# Altium schematic sheet NRC7394-RX.SchDoc
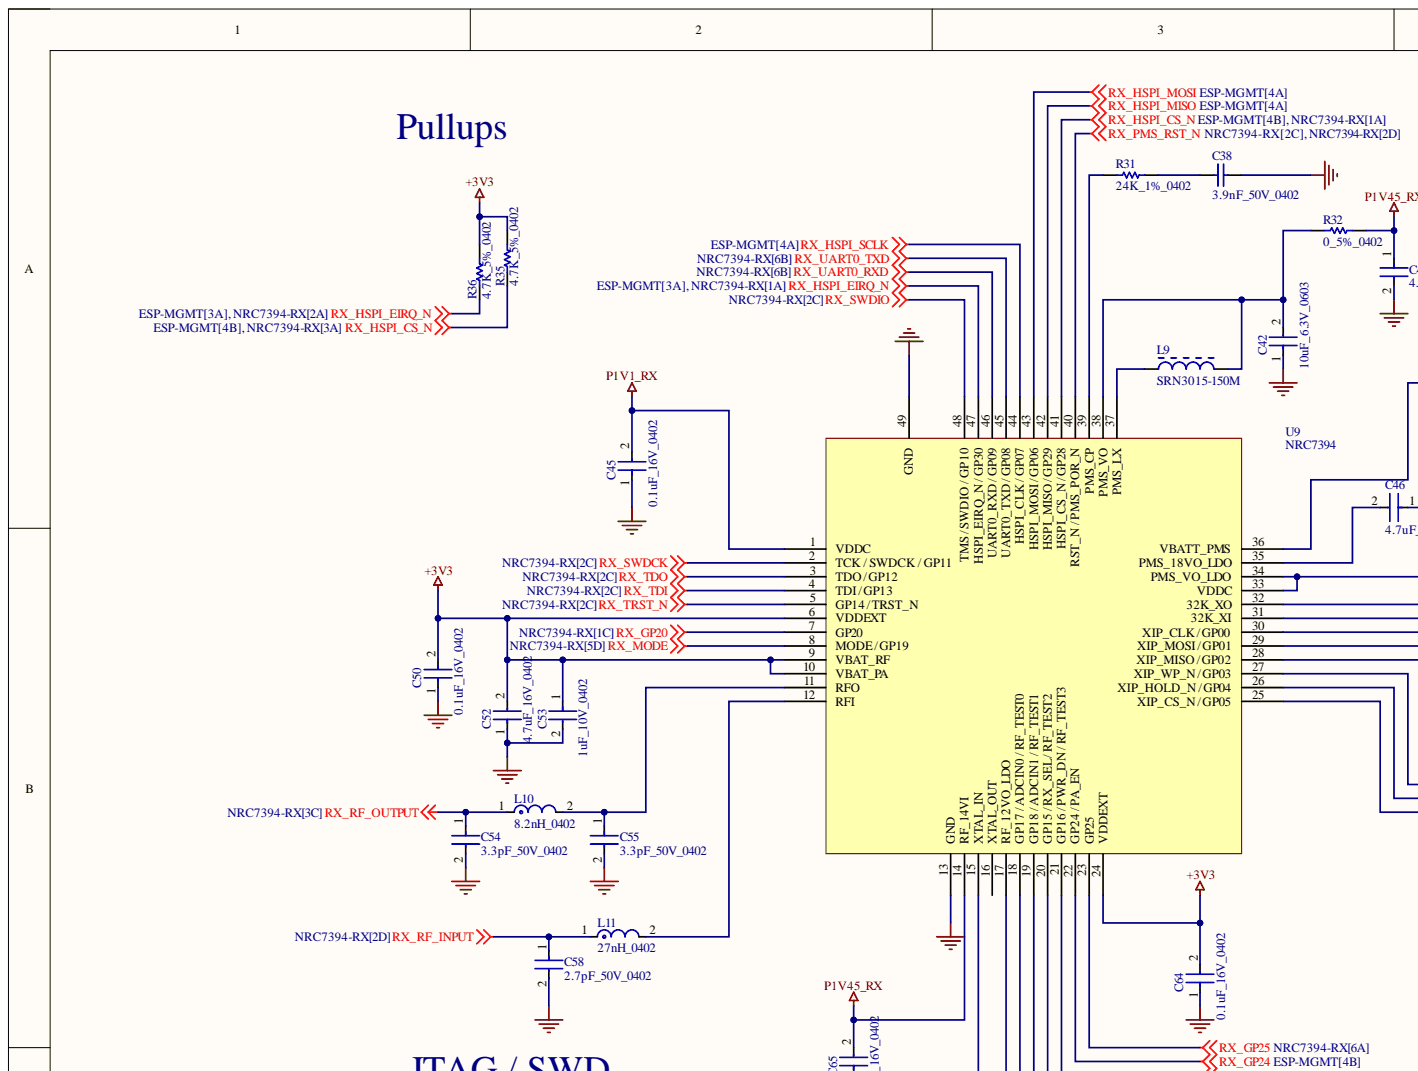
[diagram p0: NRC7394-RX.SchDoc, top left]
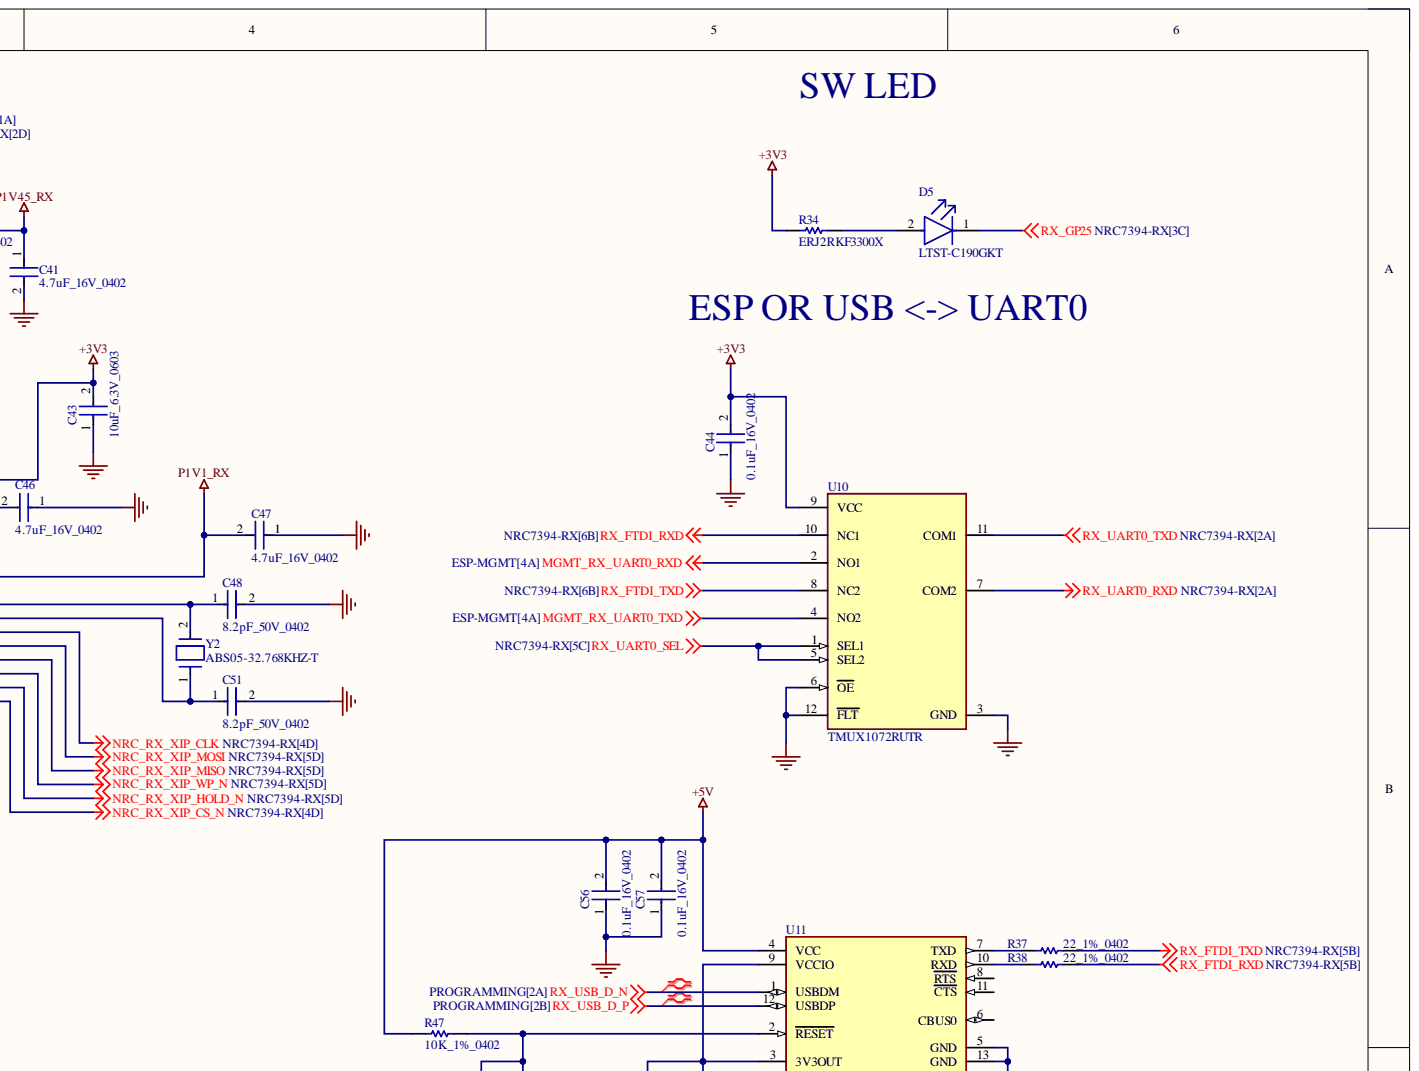
[diagram p1: NRC7394-RX.SchDoc, top right]
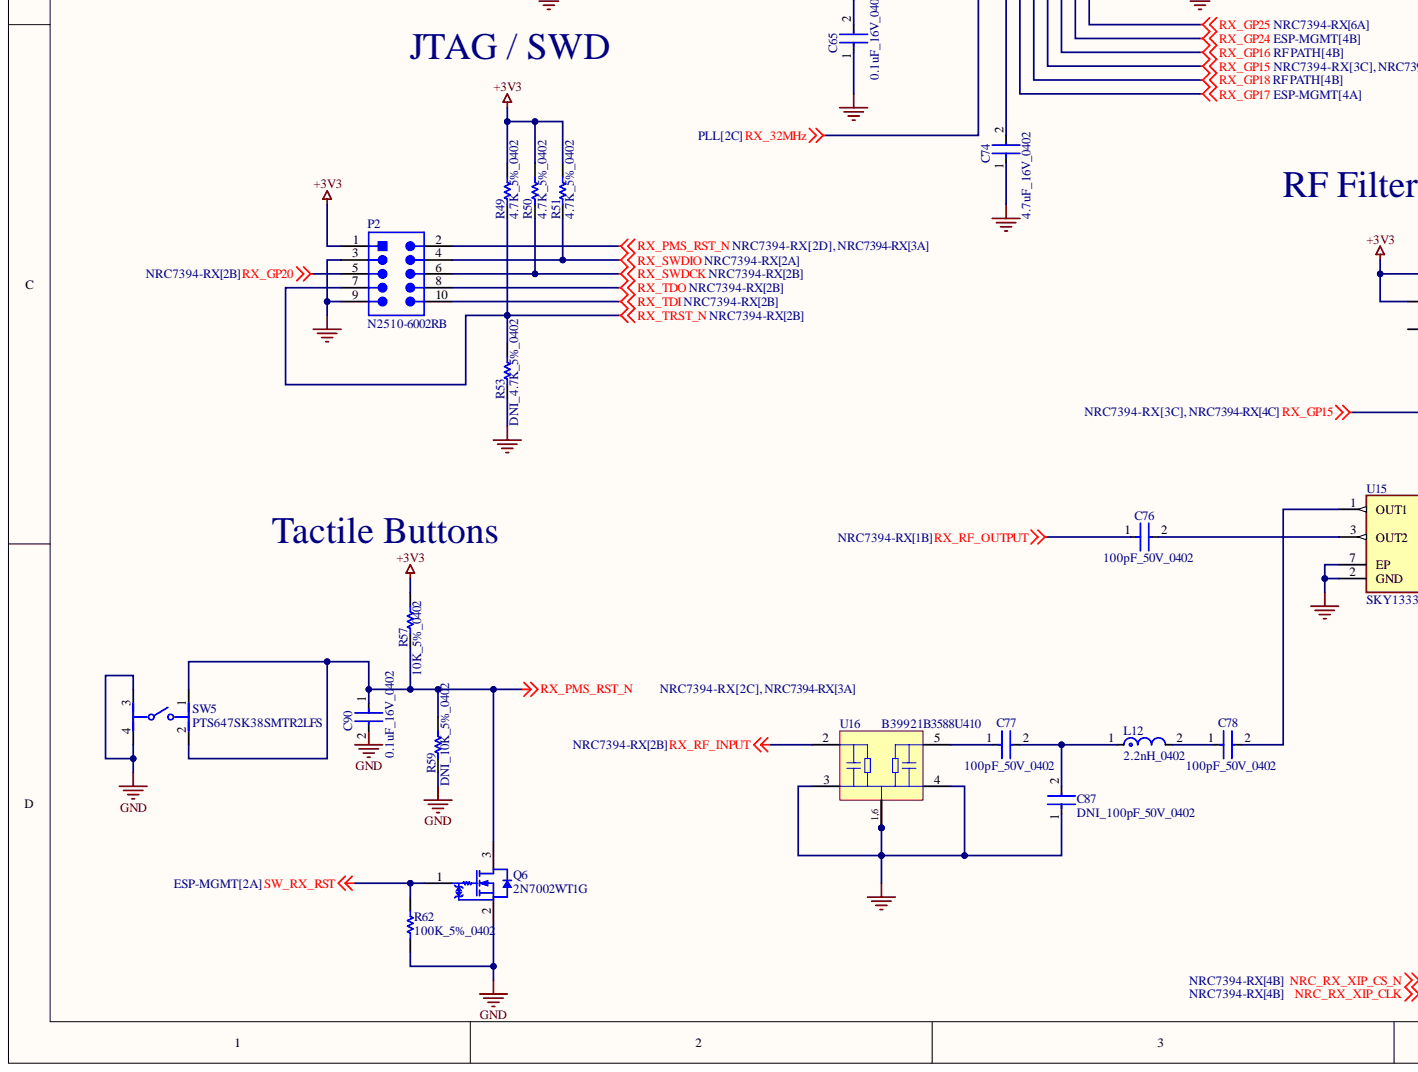
[diagram p2: NRC7394-RX.SchDoc, bottom left]
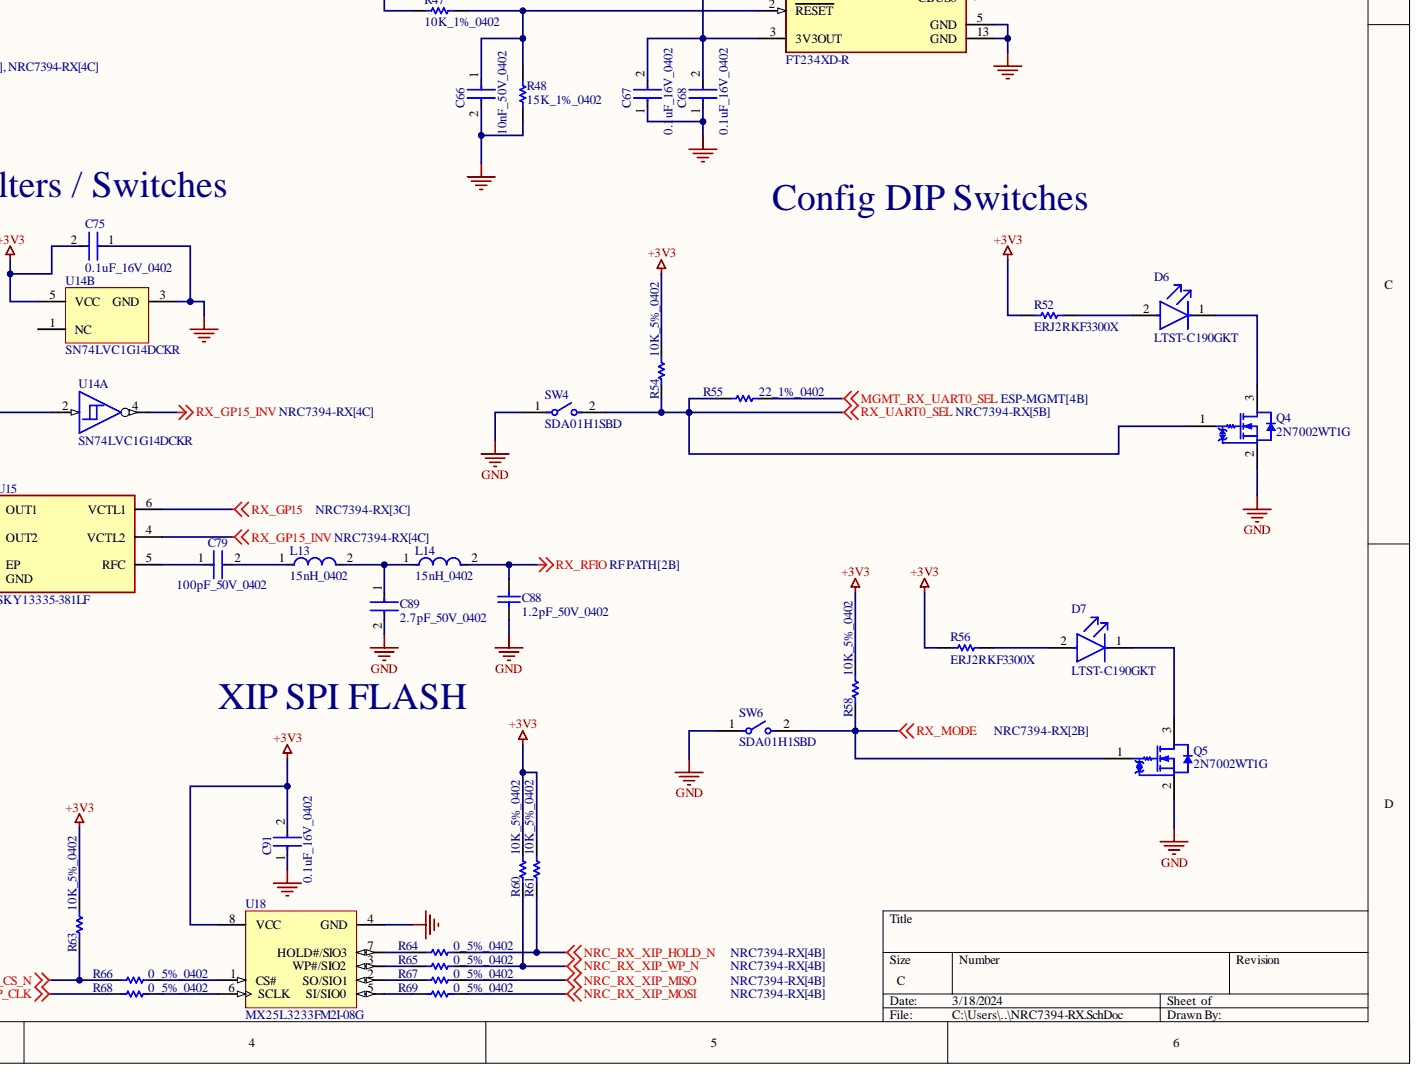
[diagram p3: NRC7394-RX.SchDoc, bottom right]

## Parts
C38  3.9nF_50V_0402  [CAPC1005X56X25LL10T15]
C41  4.7uF_16V_0402  [FP-CL829-IPC_C]
C42  10uF_6.3V_0603  [FP-GRM188-0_1-e0_2_0_55-IPC_A]
C43  10uF_6.3V_0603  [FP-GRM188-0_1-e0_2_0_55-IPC_A]
C44  0.1uF_16V_0402  [FP-C0402-BB-MFG]
C45  0.1uF_16V_0402  [FP-C0402-BB-MFG]
C46  4.7uF_16V_0402  [FP-CL829-IPC_C]
C47  4.7uF_16V_0402  [FP-CL829-IPC_C]
C48  8.2pF_50V_0402  [FP-C0402-BB-MFG]
C50  0.1uF_16V_0402  [FP-C0402-BB-MFG]
C51  8.2pF_50V_0402  [FP-C0402-BB-MFG]
C52  4.7uF_16V_0402  [FP-CL829-IPC_C]
C53  1uF_10V_0402  [FP-C0402C105K8PACTU-MFG]
C54  3.3pF_50V_0402  [FP-C0402-BB-MFG]
C55  3.3pF_50V_0402  [FP-C0402-BB-MFG]
C56  0.1uF_16V_0402  [FP-C0402-BB-MFG]
C57  0.1uF_16V_0402  [FP-C0402-BB-MFG]
C58  2.7pF_50V_0402  [FP-GJM155-0_05-IPC_A]
C64  0.1uF_16V_0402  [FP-C0402-BB-MFG]
C65  0.1uF_16V_0402  [FP-C0402-BB-MFG]
C66  10nF_50V_0402  [FP-CL165-IPC_C]
C67  0.1uF_16V_0402  [FP-C0402-BB-MFG]
C68  0.1uF_16V_0402  [FP-C0402-BB-MFG]
C74  4.7uF_16V_0402  [FP-CL829-IPC_C]
C75  0.1uF_16V_0402  [FP-C0402-BB-MFG]
C76  100pF_50V_0402  [FP-C0402-BB-MFG]
C77  100pF_50V_0402  [FP-C0402-BB-MFG]
C78  100pF_50V_0402  [FP-C0402-BB-MFG]
C79  100pF_50V_0402  [FP-C0402-BB-MFG]
C87  DNI_100pF_50V_0402  [FP-C0402-BB-MFG]  (no BOM)
C88  1.2pF_50V_0402  [CAPC1006X50X20LL10T10]
C89  2.7pF_50V_0402  [FP-GJM155-0_05-IPC_A]
C90  0.1uF_16V_0402  [FP-C0402-BB-MFG]
C91  0.1uF_16V_0402  [FP-C0402-BB-MFG]
D5  LTST-C190GKT  [FP-LTST-C190GKT-MFG]
D6  LTST-C190GKT  [FP-LTST-C190GKT-MFG]
D7  LTST-C190GKT  [FP-LTST-C190GKT-MFG]
L9  SRN3015-150M  [BOUR-SRN3015-2_V]
L10  8.2nH_0402  [FP-LQG15HH_02-IPC_B]
L11  27nH_0402  [FP-LQG15HH_02-IPC_C]
L12  2.2nH_0402  [FP-LQG15HH_02-IPC_C]
L13  15nH_0402  [FP-HK1005-MFG]
L14  15nH_0402  [FP-HK1005-MFG]
P2  N2510-6002RB  [3M-N2510-6002-10_V]
Q4  2N7002WT1G  [ONSC-SC-70-3-419-04_V]
Q5  2N7002WT1G  [ONSC-SC-70-3-419-04_V]
Q6  2N7002WT1G  [ONSC-SC-70-3-419-04_V]
R31  24K_1%_0402  [RESC1005X40X25ML05T05]
R32  0_5%_0402  [RESC1005X40X25ML05T10]
R34  ERJ2RKF3300X  [RESC1005X40X25ML05T05]
R35  4.7K_5%_0402  [RESC1005X40X25LL05T05]
R36  4.7K_5%_0402  [RESC1005X40X25LL05T05]
R37  22_1%_0402  [RESC1005X40X25LL05T10]
R38  22_1%_0402  [RESC1005X40X25LL05T10]
R47  10K_1%_0402  [RESC1005X40X25NL05T10]
R48  15K_1%_0402  [RESC1005X40X25ML05T10]
R49  4.7K_5%_0402  [RESC1005X40X25LL05T05]
R50  4.7K_5%_0402  [RESC1005X40X25LL05T05]
R51  4.7K_5%_0402  [RESC1005X40X25LL05T05]
R52  ERJ2RKF3300X  [RESC1005X40X25ML05T05]
R53  DNI_4.7K_5%_0402  [RESC1005X40X25LL05T05]  (no BOM)
R54  10K_5%_0402  [RESC1005X40X25ML05T05]
R55  22_1%_0402  [RESC1005X40X25LL05T10]
R56  ERJ2RKF3300X  [RESC1005X40X25ML05T05]
R57  10K_5%_0402  [RESC1005X40X25ML05T05]
R58  10K_5%_0402  [RESC1005X40X25ML05T05]
R59  DNI_10K_5%_0402  [RESC1005X40X25ML05T05]  (no BOM)
R60  10K_5%_0402  [RESC1005X40X25ML05T05]
R61  10K_5%_0402  [RESC1005X40X25ML05T05]
R62  100K_5%_0402  [RESC1005X37X25ML05T10]
R63  10K_5%_0402  [RESC1005X40X25ML05T05]
R64  0_5%_0402  [RESC1005X40X25ML05T10]
R65  0_5%_0402  [RESC1005X40X25ML05T10]
R66  0_5%_0402  [RESC1005X40X25ML05T10]
R67  0_5%_0402  [RESC1005X40X25ML05T10]
R68  0_5%_0402  [RESC1005X40X25ML05T10]
R69  0_5%_0402  [RESC1005X40X25ML05T10]
SW4  SDA01H1SBD  [FP-SDA01H1SBD-MFG]
SW5  PTS647SK38SMTR2LFS  [FP-PTS647SK38SMTR2LFS-MFG]
SW6  SDA01H1SBD  [FP-SDA01H1SBD-MFG]
U9  NRC7394  [QFN48_6X6]
U10  TMUX1072RUTR  [FP-RUT0012A-MFG]
U11  FT234XD-R  [FP-DFN-12-IPC_B]
U14  SN74LVC1G14DCKR  [DCK0005A_L]  (parts A,B)
U15  SKY13335-381LF  [FP-SKY13335-381LF-MFG]
U16  B39921B3588U410  [EPCO-DCC6C-6]
U18  MX25L3233FM2I-08G  [MXIC-SOP-8_M]
Y2  ABS05-32.768KHZ-T  [FP-ABS05-MFG]

## Nets
+3V3: C43.2 C44.2 C50.2 C52.2 C53.1 C64.2 C75.2 C91.2 P2.1 R34.1 R35.2 R36.2 R49.2 R50.2 R51.2 R52.1 R54.2 R56.1 R57.2 R58.2 R60.2 R61.2 R63.2 U9.6(VDDEXT) U9.9(VBAT_RF) U9.10(VBAT_PA) U9.24(VDDEXT) U9.36(VBATT_PMS) U10.9(VCC) U14.5(VCC) U18.8(VCC)
+5V: C56.2 C57.2 R47.1 U11.4(VCC)
GND: C38.2 C41.2 C42.1 C43.1 C44.1 C45.1 C46.1 C47.1 C48.2 C50.1 C51.2 C52.1 C53.2 C54.2 C55.2 C56.1 C57.1 C58.2 C64.1 C65.1 C66.2 C67.1 C68.1 C74.1 C75.1 C87.1 C88.1 C89.2 C90.2 C91.1 P2.3 P2.9 Q4.2 Q5.2 Q6.2 R48.1 R53.1 R59.1 R62.1 SW4.1 SW5.3 SW5.4 SW6.1 U9.13(GND) U9.49(GND) U10.3(GND) U10.6(OE) U10.12(FLT) U11.5(GND) U11.13(GND) U14.3(GND) U15.2(GND) U15.7(EP) U16.1 U16.3 U16.4 U16.6 U18.4(GND)
MGMT_RX_UART0_RXD: U10.2(NO1)
MGMT_RX_UART0_SEL: R55.2
MGMT_RX_UART0_TXD: U10.4(NO2)
NRC_RX_XIP_CLK: R68.1 U9.30(XIP_CLK_/_GP00)
NRC_RX_XIP_CS_N: R63.1 R66.1 U9.25(XIP_CS_N_/_GP05)
NRC_RX_XIP_HOLD_N: R61.1 R64.2 U9.26(XIP_HOLD_N_/_GP04)
NRC_RX_XIP_MISO: R67.2 U9.28(XIP_MISO_/_GP02)
NRC_RX_XIP_MOSI: R69.2 U9.29(XIP_MOSI_/_GP01)
NRC_RX_XIP_WP_N: R60.1 R65.2 U9.27(XIP_WP_N_/_GP03)
P1V1_RX: C45.2 C47.2 U9.1(VDDC) U9.33(VDDC) U9.34(PMS_VO_LDO)
P1V45_RX: C41.1 C65.2 R32.2 U9.14(RF_14VI)
RX_32MHz: U9.15(XTAL_IN)
RX_FTDI_RXD: R38.2 U10.10(NC1)
RX_FTDI_TXD: R37.2 U10.8(NC2)
RX_GP15: U9.20(GP15_/_RX_SEL_/_RF_TEST2) U14.2 U15.6(VCTL1)
RX_GP15_INV: U14.4 U15.4(VCTL2)
RX_GP16: U9.21(GP16_/_PWR_DN_/_RF_TEST3)
RX_GP17: U9.18(GP17_/_ADCIN0_/_RF_TEST0)
RX_GP18: U9.19(GP18_/_ADCIN1_/_RF_TEST1)
RX_GP20: P2.5 U9.7(GP20)
RX_GP24: U9.22(GP24_/_PA_EN)
RX_GP25: D5.1 U9.23(GP25)
RX_HSPI_CS_N: R35.1 U9.41(HSPI_CS_N_/_GP28)
RX_HSPI_EIRQ_N: R36.1 U9.47(HSPI_EIRQ_N_/_GP30)
RX_HSPI_MISO: U9.42(HSPI_MISO_/_GP29)
RX_HSPI_MOSI: U9.43(HSPI_MOSI_/_GP06)
RX_HSPI_SCLK: U9.44(HSPI_CLK_/_GP07)
RX_MODE: Q5.1 R58.1 SW6.2 U9.8(MODE_/_GP19)
RX_PMS_RST_N: C90.1 P2.2 Q6.3 R57.1 R59.2 SW5.1 SW5.2 U9.40(RST_N_/_PMS_POR_N)
RX_RF_INPUT: C58.1 L11.1 U16.2
RX_RF_OUTPUT: C54.1 C76.1 L10.1
RX_RFIO: C88.2 L14.2
RX_SWDCK: P2.6 R50.1 U9.2(TCK_/_SWDCK_/_GP11)
RX_SWDIO: P2.4 R51.1 U9.48(TMS_/_SWDIO_/_GP10)
RX_TDI: P2.10 U9.4(TDI_/_GP13)
RX_TDO: P2.8 U9.3(TDO_/_GP12)
RX_TRST_N: P2.7 R49.1 R53.2 U9.5(GP14_/_TRST_N)
RX_UART0_RXD: U9.46(UART0_RXD_/_GP09) U10.7(COM2)
RX_UART0_SEL: Q4.1 R54.1 R55.1 SW4.2 U10.1(SEL1) U10.5(SEL2)
RX_UART0_TXD: U9.45(UART0_TXD_/_GP08) U10.11(COM1)
RX_USB_D_N: U11.1(USBDM)
RX_USB_D_P: U11.12(USBDP)
SW_RX_RST: Q6.1 R62.2
NetC38_1: C38.1 R31.2
NetC42_2: C42.2 L9.2 R32.1 U9.38(PMS_VO)
NetC46_2: C46.2 U9.35(PMS_18VO_LDO)
NetC48_1: C48.1 U9.32(32K_XO) Y2.2
NetC51_1: C51.1 U9.31(32K_XI) Y2.1
NetC55_1: C55.1 L10.2 U9.11(RFO)
NetC66_1: C66.1 R47.2 R48.2 U11.2(RESET)
NetC67_2: C67.2 C68.2 U11.3(3V3OUT) U11.9(VCCIO)
NetC74_2: C74.2 U9.17(RF_12VO_LDO)
NetC76_2: C76.2 U15.3(OUT2)
NetC77_1: C77.1 U16.5
NetC77_2: C77.2 C87.2 L12.1
NetC78_1: C78.1 L12.2
NetC78_2: C78.2 U15.1(OUT1)
NetC79_1: C79.1 U15.5(RFC)
NetC79_2: C79.2 L13.1
NetC89_1: C89.1 L13.2 L14.1
NetD5_2: D5.2 R34.2
NetD6_1: D6.1 Q4.3
NetD6_2: D6.2 R52.2
NetD7_1: D7.1 Q5.3
NetD7_2: D7.2 R56.2
NetL9_1: L9.1 U9.37(PMS_LX)
NetL11_2: L11.2 U9.12(RFI)
NetR31_1: R31.1 U9.39(PMS_CP)
NetR37_1: R37.1 U11.7(TXD)
NetR38_1: R38.1 U11.10(RXD)
NetR64_1: R64.1 U18.7(HOLD#/SIO3)
NetR65_1: R65.1 U18.3(WP#/SIO2)
NetR66_2: R66.2 U18.1(CS#)
NetR67_1: R67.1 U18.2(SO/SIO1)
NetR68_2: R68.2 U18.6(SCLK)
NetR69_1: R69.1 U18.5(SI/SIO0)

Not connected: U9 16(XTAL_OUT); U11 6(CBUS0) 8(RTS) 11(CTS); U14 1(NC)

## Library data of the parts
C38 = CMP-2008-02725-1: Manufacturer: AVX Interconnect / Elco; Part Number: 04025C392JAT2A; Component Kind: Standard; Library Name: Altium Content Vault
C41 C46 C47 C52 C74 = CMP-13271-001468-1: Cap Ceramic 4.7uF 16V X5R ±20% SMD 0402 +85°C Paper T/R; Capacitance: 4.7uF; Case Code (Imperial): 0402; Case Code (Metric): 1005; Case/Package: 0402; Component Kind: Standard; Length: 0.039inch; Library Name: Altium Content Vault; Max Operating Temperature: 85°C; Min Operating Temperature: -55°C; Mount: Surface Mount; Packaging: Tape and Reel; Thickness: 0.022inch; Tolerance: 20%; Voltage Rating: 16V; Width: 0.02inch
C42 C43 = CMP-2006-02792-2: Chip Multilayer Ceramic Capacitors for General Purpose, 0603, 10uF, X5R, 15%, 20%, 6.3V; Capacitance: 10uF; Case Code (Imperial): 0603; Case Code (Metric): 1608; Case/Package: 0603; Component Kind: Standard; Depth: 0.8mm; Dielectric: X5R; Height: 0.8mm; Lead Free: Lead Free; Length: 1.6mm; Library Name: Altium Content Vault; Material: Ceramic; Max Operating Temperature: 85°C; Min Operating Temperature: -55°C; Mount: Surface Mount; Package Quantity: 4000; Packaging: Tape and Reel; Radiation Hardening: No; REACH SVHC: No SVHC; Termination: SMD/SMT; Thickness: 0.035inch; Tolerance: 20%; Voltage: 6.3V; Voltage Rating: 6.3V; Voltage Rating (DC): 6.3V; Width: 0.031inch
C44 C45 C50 C56 C57 C64 C65 C67 C68 C75 C90 C91 = CMP-2008-02094-2: CAP CER 0.1UF 16V 20% X7R 0402; Manufacturer: Kemet; Manufacturer Part Number: C0402C104M4RACTU; Capacitance: 0.10 μF; Material: Ceramic; Temperature Coefficient: 15%; Tolerance: 0.2; Value: 0.10 μF; Automotive: No; Category: Cap; Component Kind: Standard; ComponentLink2Description: Datasheet; ComponentLink2URL: https://content.kemet.com/datasheets/KEM_C1002_X7R_SMD.pdf; Device Class L1: Passive Components; Device Class L2: Capacitors; Device Class L3: Ceramic Capacitors; Digikey Description: CAP CER 0.1UF 16V 20% X7R 0402; Height: 0.55mm; Ipc Land Pattern Name: CAPC10050X50; Lead Free: Yes; Library Name: Altium Content Vault; Mouser Description: Multilayer Ceramic Capacitors MLCC - SMD/SMT 16volts 0.1uF X7R 20%; Octopart: https://octopart.com/c0402c104m4ractu-kemet-10476575; Package: C0402-BB; RoHS: TRUE; Temperature: -55°C to +125°C; Temperature Characteristic: X7R; Temperature Range High: +125°C; Temperature Range Low: -55°C; Voltage Rating: 16 V
C48 C51 = CMP-1034-00608-3: CAP CER 8.2PF 50V NP0 0402; Manufacturer: Kemet; Manufacturer Part Number: C0402C829C5GACTU; Material: Ceramic; Temperature Characteristic: C0G; Temperature Coefficient: 15%; Tolerance: 0.25 pF; Automotive: No; Capacitance: 8.2 pF; Category: Cap; Component Kind: Standard; ComponentLink2Description: Datasheet; ComponentLink2URL: https://content.kemet.com/datasheets/KEM_C1002_X7R_SMD.pdf; Device Class L1: Passive Components; Device Class L2: Capacitors; Device Class L3: Ceramic Capacitors; Digikey Description: CAP CER 8.2PF 50V NP0 0402; Height: 0.55mm; Ipc Land Pattern Name: CAPC10050X50; Lead Free: Yes; Library Name: Altium Content Vault; Mouser Description: Multilayer Ceramic Capacitors MLCC - SMD/SMT 50volts 8.2pF C0G .25pF; Octopart: https://octopart.com/c0402c829c5gactu-kemet-9144065; Package: C0402-BB; RoHS: TRUE; Temperature: -55°C to +125°C; Temperature Range High: +125°C; Temperature Range Low: -55°C; Value: 8.2 pF; Voltage Rating: 50 V
C53 = CMP-2008-02081-2: CAP CER 1UF 10V X5R 0402; Dielectric Material: Ceramic; Dissipation Factor: 5%; Tolerance: 10%; Capacitance: 1uF; Case Code (Imperial): 0402; Case Code (Metric): 1005; Case/Package: 0402; Component Kind: Standard; Construction: Flat; Contact Plating: Tin; Depth: 0.5mm; Dielectric: X5R; Height: 0.5mm; Lead Free: Lead Free; Length: 1mm; Library Name: Altium Content Vault; Max Operating Temperature: 85°C; Min Operating Temperature: -55°C; Mount: Surface Mount; Number of Pins: 2; Package Quantity: 1; Packaging: Tape and Reel; Radiation Hardening: No; REACH SVHC: No SVHC; RoHS Compliant: Yes; Series: C; Termination: SMD/SMT; Thickness: 0.022inch; Voltage: 10V; Voltage Rating: 10V; Voltage Rating (DC): 10V; Width: 0.5mm
C54 C55 = CMP-2008-03312-2: Capacitor: ceramic; MLCC; 3.3pF; 50VDC; C0G; ±0.25pF; SMD; 0402; Manufacturer: Kemet; Manufacturer Part Number: C0402C339C5GACTU; Temperature Coefficient: 15%; Voltage Rating: 50 V; Automotive: No; Capacitance: 3.3 pF; Category: Cap; Component Kind: Standard; ComponentLink2Description: Datasheet; ComponentLink2URL: https://content.kemet.com/datasheets/KEM_C1002_X7R_SMD.pdf; Device Class L1: Passive Components; Device Class L2: Capacitors; Device Class L3: Ceramic Capacitors; Digikey Description: Capacitor: ceramic; MLCC; 3.3pF; 50VDC; C0G; ±0.25pF; SMD; 0402; Height: 0.55mm; Ipc Land Pattern Name: CAPC10050X50; Lead Free: Yes; Library Name: Altium Content Vault; Material: Ceramic; Mouser Description: Multilayer Ceramic Capacitors MLCC - SMD/SMT 50volts 3.3pF C0G .25pF; Octopart: https://octopart.com/c0402c339c5gactu-kemet-105751; Package: C0402-BB; RoHS: TRUE; Temperature: -55°C to +125°C; Temperature Characteristic: C0G; Temperature Range High: +125°C; Temperature Range Low: -55°C; Tolerance: 0.25 pF; Value: 3.3 pF
C58 C89 = CMP-2008-03840-2: Multi-Layer Ceramic Capacitor 2.7pF C0G  ±0.1pF 0402 Paper T/R; Temperature Coefficient: 30ppm/°C; Voltage: 50V; Capacitance: 2.7pF; Case Code (Imperial): 0402; Case Code (Metric): 1005; Case/Package: 0402; Component Kind: Standard; Depth: 0.5mm; Dielectric: C0G; Height: 0.5mm; Lead Free: Lead Free; Lead Pitch: 0.3mm; Length: 1mm; Library Name: Altium Content Vault; Max Operating Temperature: 125°C; Min Operating Temperature: -55°C; Mount: Surface Mount; Number of Pins: 2; Package Quantity: 10000; Packaging: Tape and Reel; Radiation Hardening: No; REACH SVHC: No SVHC; Termination: SMD/SMT; Thickness: 0.022inch; Voltage Rating: 50V; Voltage Rating (DC): 50V; Width: 0.02inch
C66 = CMP-13271-000387-1: Cap Ceramic 10nF 50V X7R ±10% SMD 0402 +125°C Paper T/R; Capacitance: 10nF; Case Code (Imperial): 0402; Case Code (Metric): 1005; Case/Package: 0402; Component Kind: Standard; Contact Plating: Tin; Depth: 0.5mm; Dielectric: X7R; Height: 0.5mm; Lead Free: Lead Free; Length: 1mm; Library Name: Altium Content Vault; Material: Ceramic; Max Operating Temperature: 125°C; Min Operating Temperature: -55°C; Mount: Surface Mount; Package Quantity: 1; Packaging: Tape and Reel; Radiation Hardening: No; Thickness: 0.022inch; Tolerance: 10%; Voltage: 50V; Voltage Rating: 50V; Voltage Rating (DC): 50V; Width: 0.02inch
C76-C79 C87 = CMP-2008-03284-2: CAP CER 100PF 50V C0G/NP0 0402; Manufacturer: Kemet; Manufacturer Part Number: C0402C101J5GACTU; Material: Ceramic; Temperature Characteristic: C0G; Temperature Coefficient: 15%; Tolerance: 0.05; Automotive: No; Capacitance: 100 pF; Category: Cap; ComponentLink2Description: Datasheet; ComponentLink2URL: https://content.kemet.com/datasheets/KEM_C1002_X7R_SMD.pdf; Device Class L1: Passive Components; Device Class L2: Capacitors; Device Class L3: Ceramic Capacitors; Digikey Description: CAP CER 100PF 50V C0G/NP0 0402; Height: 0.55mm; Ipc Land Pattern Name: CAPC10050X50; Lead Free: Yes; Library Name: Altium Content Vault; Mouser Description: Multilayer Ceramic Capacitors MLCC - SMD/SMT 50volts 100pF C0G 5%; Octopart: https://octopart.com/c0402c101j5gactu-kemet-132471; Package: C0402-BB; RoHS: TRUE; Temperature: -55°C to +125°C; Temperature Range High: +125°C; Temperature Range Low: -55°C; Value: 100 pF; Voltage Rating: 50 V
  C76-C79: Component Kind: Standard
C88 = CMP-2008-04752-1: Manufacturer: AVX Interconnect / Elco; Part Number: 04023J1R2BBSTR; Component Kind: Standard; Library Name: Altium Content Vault
D5-D7 = CMP-22017-000005-2: LED GREEN CLEAR CHIP SMD; Dominant Wavelength: 569nm; Forward Current: 30mA; Forward Voltage: 2.1V; Lens Color: Colorless; Luminous Intensity: 6mcd; Number of Elements: 1; Power Dissipation: 100mW; Reverse Voltage: 5V; Test Current: 10mA; Viewing Angle: 130°; Voltage: 2V; Wavelength: 565nm; Case Code (Imperial): 0603; Case Code (Metric): 1608; Case/Package: 0603; Color: Green; Component Kind: Standard; Depth: 0.8mm; Height: 0.8mm; Illumination Color: Green; Lead Free: Lead Free; Length: 1.6mm; Lens Style: Clear; Lens Transparency: Clear; Library Name: Altium Content Vault; Max Operating Temperature: 85°C; Min Operating Temperature: -55°C; Mount: Surface Mount; Number of LEDs: 1; Number of Pins: 2; Package Quantity: 1; Packaging: Tape and Reel; Power Rating: 100mW; Radiation Hardening: No; Width: 0.8mm
L9 = CMP-0007-00012-1: Semi-shielded Power Inductor, 15 uH, +/- 20%, 0.8 A, -40 to 125 degC, 3 x 3 x 1.5 mm SMD, RoHS and Halogen Free, Tape and Reel; Manufacturer: Bourns; DCR Max: 0.422 Ohm; Inductance: 15 uH; Inductance tolerance: +/- 20%; Isat: 0.68 A; Rated current: 0.8 A; Resistance to Solder Heat: +260 degC for 10 sec.; SRF Min: 33 MHz; Component Kind: Standard; ComponentLink1Description: Manufacturer URL; ComponentLink1URL: http://www.bourns.com/; ComponentLink2Description: Datasheet; ComponentLink2URL: http://www.bourns.com/data/global/pdfs/SRN3015.pdf; ComponentLink3Description: Package Specification; ComponentLink3URL: http://www.bourns.com/data/global/pdfs/SRN3015.pdf; DatasheetVersion: Aug-11; Library Name: Altium Content Vault; Mounting Technology: Surface Mount; Operating Temperature: -40 to 125 degC; PackageDescription: 2-Pin SMD, Body 3 x 3 mm, Height 1.5 mm; PackageReference: SRN3015; Packing: Tape and Reel; PartNumber: SRN3015-150M; RoHS: TRUE
L10 = CMP-06042-007420-1: Multilayer type RF Inductor 8.2nH ±5% 0.24Ω 550mA 0402; Core Material: Air; Current Rating: 300mA; DC Resistance (DCR): 240mR; Q Factor: 8; Self Resonant Frequency: 3.7GHz; Shielding: Unshielded; Test Frequency: 100MHz; Case Code (Imperial): 0402; Case Code (Metric): 1005; Case/Package: 0402; Component Kind: Standard; DC Current: 300mA; Depth: 0.55mm; Height: 0.5mm; Height - Seated (Max): 0.022inch; Inductance: 8.2nH; Lead Free: Lead Free; Length: 1mm; Library Name: Altium Content Vault; Max DC Current: 300mA; Max Operating Temperature: 125°C; Military Standard: Not; Min Operating Temperature: -55°C; Mount: Surface Mount; Package Quantity: 10000; Packaging: Tape and Reel; Radiation Hardening: No; Termination: SMD/SMT; Tolerance: 5%; Weight: 2.3E-05oz; Width: 0.02inch
L11 = CMP-2000-06578-2: Multilayer type RF Inductor 27nH ±5% 0.46Ω 350mA 0402; Core Material: Air; Current Rating: 300mA; DC Resistance (DCR): 460mR; Q Factor: 8; Self Resonant Frequency: 1.7GHz; Shielding: Unshielded; Test Frequency: 100MHz; Case Code (Imperial): 0402; Case Code (Metric): 1005; Case/Package: 0402; Component Kind: Standard; DC Current: 300mA; Depth: 0.55mm; Height: 0.5mm; Height - Seated (Max): 0.022inch; Inductance: 27nH; Inductance Tolerance: 5%; Lead Free: Lead Free; Length: 1mm; Library Name: Altium Content Vault; Max DC Current: 300mA; Max Operating Temperature: 125°C; Military Standard: Not; Min Operating Temperature: -55°C; Mount: Surface Mount; Package Quantity: 10000; Packaging: Tape and Reel; REACH SVHC: No SVHC; Termination: SMD/SMT; Tolerance: 5%; Width: 0.02inch
L12 = CMP-1662-00024-2: Multilayer type RF Inductor 2.2nH ±0.3nH 0.09Ω 900mA 0402; Core Material: Air; Current Rating: 300mA; DC Resistance (DCR): 150mR; Q Factor: 8; Self Resonant Frequency: 6GHz; Shielding: Unshielded; Test Frequency: 100MHz; Case Code (Imperial): 0402; Case Code (Metric): 1005; Case/Package: 0402; Component Kind: Standard; DC Current: 300mA; Depth: 0.55mm; Height: 0.02inch; Height - Seated (Max): 0.022inch; Inductance: 2.2nH; Lead Free: Lead Free; Length: 1mm; Library Name: Altium Content Vault; Max DC Current: 300mA; Max Operating Temperature: 125°C; Military Standard: Not; Min Operating Temperature: -55°C; Mount: Surface Mount; Number of Pins: 2; Package Quantity: 10000; Packaging: Tape and Reel; Radiation Hardening: No; REACH SVHC: No SVHC; Termination: SMD/SMT; Width: 0.02inch
L13 L14 = CMP-14484-000027-1: FIXED IND 15NH 320MA 460 MOHM; Body Material: Ceramic; Core Material: Ferrite; DC Resistance (DCR): 460mR; Inductance Tolerance: 5%; Max DC Current: 300mA; Q Factor: 8; Self Resonant Frequency: 2.3GHz; Shielding: Unshielded; Test Frequency: 100MHz; Case Code (Imperial): 0402; Case Code (Metric): 1005; Case/Package: 0402; Component Kind: Standard; Current Rating: 320mA; DC Current: 320mA; Depth: 0.55mm; Halogen Free: Halogen Free; Height: 0.5mm; Height - Seated (Max): 0.022inch; Inductance: 15nH; Lead Free: Exempt; Length: 0.039inch; Library Name: Altium Content Vault; Max Operating Temperature: 125°C; Military Standard: Not; Min Operating Temperature: -55°C; Mount: Surface Mount; Number of Pins: 2; Package Quantity: 10000; Packaging: Tape and Reel; Radiation Hardening: No; RoHS Compliant: Yes; Series: HK; Termination: SMD/SMT; Tolerance: 5%; Width: 0.02inch
P2 = CMP-2000-06794-1: Male Header, Pitch 2.54 mm, 2 x 5 Postion, Height 9.91 mm, Tail Length 2.84 mm, -55 to 105 degC, RoHS, Bulk; Manufacturer: 3M; PartNumber: N2510; No. of Positions: 10; No. of Rows: 2; Package Version: Rev. M, 08/2014; Pitch: 2.54mm; Rad Hard: No; Component Kind: Standard; Contact Plating: GoldOverNickel; Datasheet URL: https://datasheet.ciiva.com/11746/0900766b807b18da-11746957.pdf; Datasheet Version: 05/2007; Gender: Male; Library Name: Altium Content Vault; Manufacturer URL: http://www.3m.com/; Mounting Technology: ThroughHole; Number of Contacts: 10; Number of Rows: 2; Orientation: Straight; Package Description: 10-Pin Through Hole Device, Body 20.02 x 8.59 mm, Pitch 2.54 mm; Package Reference: N2510-6002-10; Package URL: http://multimedia.3m.com/mws/media/330367O/3mtm-100-in-4-wall-hdr-rohs-lp-straightra-hitemp-ts0770.pdf; Packaging: Rail/Tube; Termination Method: Solder; Type: ShroudedHeader
Q4-Q6 = CMP-1058-00618-1: Small Signal MOSFET, 60 V, 340 mA, Single, N-Channel, 3-Pin SC70, Pb-Free, Tape and Reel; Manufacturer: On Semiconductor; Channel Polarity: N Channel; Ciss Typ (pF): 24.5; Coss Typ (pF): 4.2; Crss Typ (pF): 2.2; ID Max (A): 0.34; V(BR)DSS Min (V): 60; VGS Max (V): 20; VGS(th) Max (V): 2.5; Component Kind: Standard; ComponentLink1Description: Manufacturer URL; ComponentLink1URL: http://www.onsemi.com/; ComponentLink2Description: Datasheet; ComponentLink2URL: http://www.onsemi.com/pub_link/Collateral/2N7002W-D.PDF; ComponentLink3Description: Package Specification; ComponentLink3URL: http://www.onsemi.cn/pub_link/Collateral/419-04.PDF; Configuration: Single; DatasheetVersion: Rev. 5; Library Name: Altium Content Vault; Mounting Technology: Surface Mount; Package Type: SC-70-3 / SOT-323-3; PackageDescription: 3-Pin Small Outline Transistor, Body 2 x 1.25 mm, Pitch 0.65 mm; PackageReference: SC-70-3-419-04; PackageVersion: Rev. N, 11/2008; Packing: Tape and Reel; PartNumber: 2N7002WT1G; RoHS: Pb-Free
R31 = CMP-2002-08403-1: Manufacturer: Vishay Dale; Part Number: CRCW040224K0FKED; Component Kind: Standard; Library Name: Altium Content Vault
R32 R64-R69 = CMP-2002-06457-1: Manufacturer: Panasonic; Part Number: ERJ-U020R00X; Component Kind: Standard; Library Name: Altium Content Vault
R34 R52 R56 = CMP-2002-01143-1: Manufacturer: Panasonic; Component Kind: Standard; Library Name: Altium Content Vault; Part Number: ERJ2RKF3300X
R35 R36 R49-R51 R53 = CMP-1011-00090-2: Manufacturer: Vishay Dale; Part Number: CRCW04024K70JNTD; Library Name: Altium Content Vault
  R35 R36 R49-R51: Component Kind: Standard
R37 R38 R55 = CMP-2002-07520-1: Manufacturer: Yageo / Phycomp; Part Number: RC0402FR-0722RL; Component Kind: Standard; Library Name: Altium Content Vault
R47 = CMP-2002-06487-1: Manufacturer: Multicomp; Part Number: MCMR04X103 JTL; Component Kind: Standard; Library Name: Altium Content Vault
R48 = CMP-2002-08068-1: Manufacturer: Yageo; Part Number: RC0402JR-1315KL; Component Kind: Standard; Library Name: Altium Content Vault
R54 R57-R61 R63 = CMP-2002-01181-1: Manufacturer: Panasonic; Part Number: ERT-J0EM103J; Library Name: Altium Content Vault
  R54 R57 R58 R60 R61 R63: Component Kind: Standard
R62 = CMP-2002-01850-1: Manufacturer: Yageo / Phycomp; Part Number: RE0402DR-07100KL; Component Kind: Standard; Library Name: Altium Content Vault
SW4 SW6 = CMP-10033-000001-1: SWITCH SLIDE DIP SPST 25MA 24V; Manufacturer: C&K Components; Contact Resistance: 50mΩ; Electromechanical Life: 1000Cycles; Number Of Circuits: 1; Standoff Height: 0.037inch; Automotive: No; Category: Switch; Component Kind: Standard; ComponentLink2Description: Datasheet; ComponentLink2URL: https://www.ckswitches.com/media/1327/sda.pdf; Contact Current Rating: 25mA; Device Class L1: Electromechanical; Device Class L2: Switches; Device Class L3: DIP Switches; Digikey Description: SWITCH SLIDE DIP SPST 25MA 24V; Height: 0.214inch; Lead Free: Yes; Library Name: Altium Content Vault; Manufacturer Part Number: SDA01H1SBD; Mouser Description: DIP Switches / SIP Switches 1 Pos SPST SMT SDA Series DIP SW; Octopart: https://octopart.com/sda01h1sbd-c%26k+components-7089741; Package: SDA01H1SBD; RoHS: TRUE; Temperature: -40°C to +85°C; Temperature Range High: +85°C; Temperature Range Low: -40°C; Throw Configuration: SPST; Voltage Rating Dc: 24V
SW5 = CMP-10040-000033-1: TACT 4.5 X 4.5, 3.8 MM H, 2.5N,; Actuator Type: Standard; Illumination Type: Non-Illuminated; Mechanical Life: 100000Cycles; Operating Force: 250gf; Ratings: IP40; Switch Function: Off-Mom; Switch Travel: 0.25mm; Throw Configuration: SPST; Component Kind: Standard; Library Name: Altium Content Vault; Max Operating Temperature: 70°C; Min Operating Temperature: -20°C; Mount: Surface Mount; Packaging: Tape and Reel; Termination: Gull Wing
U9 = NRC7394: NRC7394 WiFi HaLow chipset; Component Kind: Standard; Library Name: NRC7394.SchLib
U10 = CMP-04892-000114-1: IC SWITCH SPDT DUAL 12UQFN; -3db Bandwidth: 1.2GHz; Number of Channels: 2; On-State Resistance: 18R; Component Kind: Standard; Library Name: Altium Content Vault; Max Operating Temperature: 125°C; Min Operating Temperature: -40°C; Number of Circuits: 2; Number of Pins: 12; Packaging: Tape and Reel; RoHS Compliant: Yes
U11 = CMP-0248-00027-3: IC USB SERIAL BASIC UART 12DFN; Data Rate: 3Mbps; Max Supply Voltage: 5.5V; Min Supply Voltage: 2.97V; Number of Ports: 1; Operating Supply Current: 8mA; Operating Supply Voltage: 5V; Case/Package: DFN; Component Kind: Standard; Height: 0.75mm; Interface: UART; Lead Free: Lead Free; Length: 3mm; Library Name: Altium Content Vault; Max Operating Temperature: 85°C; Min Operating Temperature: -40°C; Mount: Surface Mount; Number of Pins: 12; Number of Transceivers: 1; Package Quantity: 5000; Packaging: Tape and Reel; REACH SVHC: No SVHC; Width: 3mm
U14 = CMP-0859-00440-4: Single Schmitt-Trigger Inverter, DCK0005A, LARGE T&R; Manufacturer: Texas Instruments; 3-State Output: No; Bits(#): 1; F @ Nom Voltage(Max)(Mhz): 150; ICC @ Nom Voltage(Max)(mA): 0.01; Input Type: CMOS/TTL; Logic: Inverting; Output Drive (IOL/IOH)(Max)(mA): 32/-32; Output Type: CMOS; Rating: Catalog; Schmitt Trigger: Yes; Sub-Family: Inverting Buffer/Driver; Technology Family: LVC; tpd @ Nom Voltage(Max)(ns): 11,6.5,5.5,5; VCC(Max)(V): 5.5; VCC(Min)(V): 1.65; Voltage(Nom)(V): 1.8,2.5,3.3,5; Component Kind: Standard; DatasheetVersion: SCES218W; Generic Part Number: SN74LVC1G14; Library Name: Altium Content Vault; Operating Temperature Range(C): -40 to 125,-40 to 85; PackageReference: DCK0005A
U15 = CMP-85923-000005-1: IC RF SWITCH SPDT 6GHZ 6MLPD; IIP3: 41dBm; Impedance: 50R; Insertion Loss: 0.5dB; Number of Switches: 1; Operating Frequency: 100 MHz to 6 GHz; P1dB: 26dBm; Turn-Off Delay Time: 50ns; Case/Package: QFN; Component Kind: Standard; Frequency: 6GHz; Lead Free: Lead Free; Library Name: Altium Content Vault; Max Operating Temperature: 85°C; Min Operating Temperature: -40°C; Number of Pins: 6; Package Quantity: 3000; Packaging: Tape and Reel; Radiation Hardening: No; RoHS Compliant: Yes; Series: -; Throw Configuration: SPDT; Turn-On Delay Time: 50ns
U16 = CMP-2000-05901-1: SAW RF Filter, 915 MHz, -45 to 125 degC, 6-Pin SMD, RoHS, Tape and Reel; Manufacturer: EPCOS; Center Frequency: 915MHz; No. of Pins: 6; Pass Band Frequency: 902MHzto928MHz; SAW Filter Applications: RemoteControlReceivers; SVHC: ToBeAdvised; Component Kind: Standard; Datasheet URL: https://datasheet.ciiva.com/1127/1598293-1127012.pdf; Datasheet Version: Rev. 2.3, 08/2008; Filter Case Style: SMD; Library Name: Altium Content Vault; Manufacturer URL: https://en.tdk.eu/; Mounting Technology: SurfaceMount; Package Description: 6-Pin Surface Mount Device, Body 3 x 3 mm, Pitch 1.2 mm; Package Reference: DCC6C
U18 = CMP-2000-05278-1: CMOS MXSMIO(R) (Serial Multi I/O) Flash Memory, 32 Mbits (x1/x2/x4), 3 V, -40 to 85 degC, 8-Pin SOP; Manufacturer: Macronix; Component Kind: Standard; Datasheet URL: http://datasheet.octopart.com/MX25L3233FM2I-08G-Macronix-datasheet-38883555.pdf; Datasheet Version: Rev. 1.1, 01/2015; Library Name: Altium Content Vault; Manufacturer URL: http://www.macronix.com; Package Description: 8-Pin Small Outline Package, Body 5.23 x 5.28 mm, Pitch 1.27 mm; Package Reference: SOP-8
Y2 = CMP-27762-008890-2: Crystal 32.768kHz ±20ppm (Tol) 12.5pF FUND 90kΩ 2-Pin SMD T/R; ESR (Equivalent Series Resistance): 90kR; Case/Package: CSMD; Component Kind: Standard; Depth: 1mm; Frequency: 32.768kHz; Frequency Tolerance: 20ppm; Height: 0.5mm; Lead Free: Lead Free; Length: 1.6mm; Library Name: Altium Content Vault; Load Capacitance: 12.5pF; Max Operating Temperature: 85°C; Min Operating Temperature: -40°C; Mount: Surface Mount; Number of Pins: 2; Operating Frequency: 32.768 kHz; Package Quantity: 3000; Packaging: Tape and Reel; Radiation Hardening: No; REACH SVHC: No SVHC; RoHS Compliant: Yes; Series: ABS05; Series Resistance: 90kR; Termination: SMD/SMT; Tolerance: 20ppm; Width: 1mm
